# Altium schematic sheet RainbowClock.SchDoc
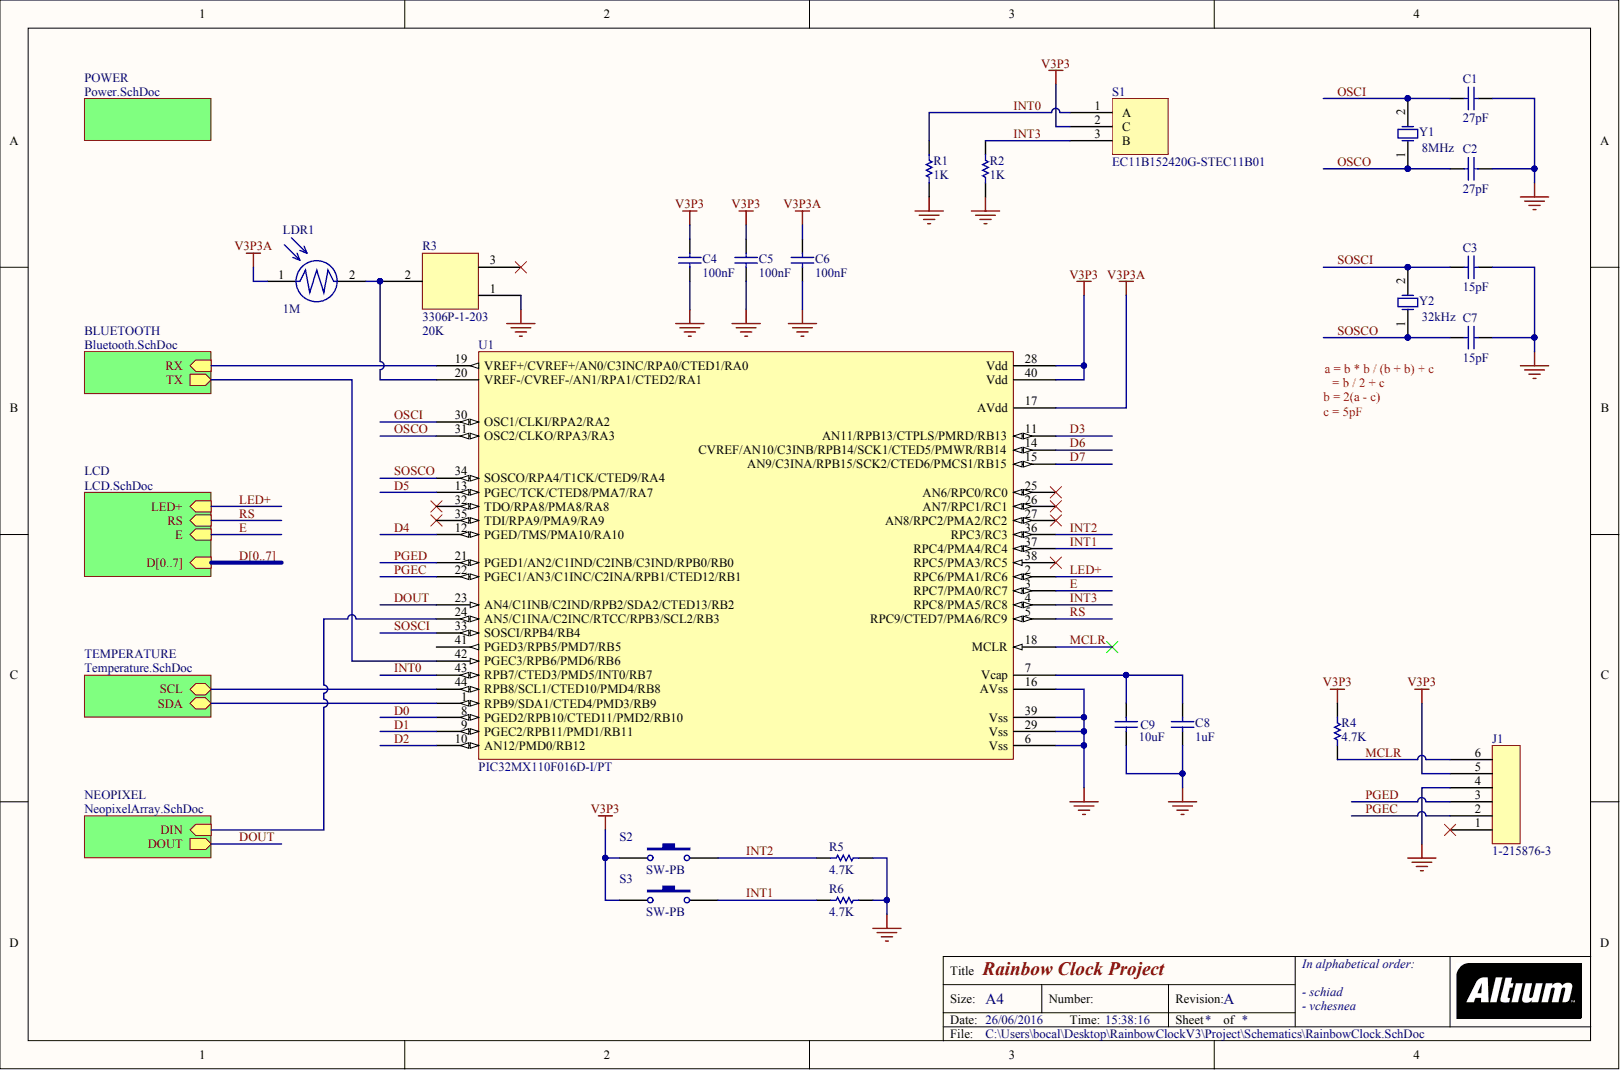
[diagram p0: RainbowClock.SchDoc]

## Parts
C1  27pF  [CAP0603]
C2  27pF  [CAP0603]
C3  15pF  [CAP0603]
C4  100nF  [CAP0603]
C5  100nF  [CAP0603]
C6  100nF  [CAP0603]
C7  15pF  [CAP0603]
C8  1uF  [CAP0603]
C9  10uF  [CAP0603]
J1  1-215876-3  [1-215876-3]
LDR1  LDR  value 1M  [VT935G]
R1  1K  [RES0603]
R2  1K  [RES0603]
R3  3306P-1-203  value 20K  [3306P-1-202]
R4  4.7K  [RES0603]
R5  4.7K  [RES0603]
R6  4.7K  [RES0603]
S1  EC11B152420G-STEC11B01  [EC11B152420G-STEC11B01]
S2  SW-PB  [1-1825027-4]
S3  SW-PB  [1-1825027-4]
U1  PIC32MX110F016D-I/PT  [PIC32MX110F016H-I/PT]  (value not drawn)
Y1  value 8MHz  [FOXSLF/080-20]  (hidden comment: 8MHz XTAL)
Y2  value 32kHz  [NCL38LF]  (hidden comment: 32kHz XTAL)

## Nets
GND: C1.2 C2.2 C3.2 C4.1 C5.1 C6.1 C7.2 C8.1 C9.1 J1.4 R1.2 R2.1 R3.1 R5.1 R6.1 U1.6(Vss) U1.16(AVss) U1.29(Vss) U1.39(Vss)
V3P3: C4.2 C5.2 J1.5 R4.2 S1.2 S2.1 S3.2 U1.28(Vdd) U1.40(Vdd)
V3P3A: C6.2 LDR1.1 U1.17(AVdd)
D0: U1.8(PGED2/RPB10/CTED11/PMD2/RB10)  [also labelled D]
D1: U1.9(PGEC2/RPB11/PMD1/RB11)  [also labelled D]
D2: U1.10(AN12/PMD0/RB12)  [also labelled D]
D3: U1.11(AN11/RPB13/CTPLS/PMRD/RB13)  [also labelled D]
D4: U1.12(PGED/TMS/PMA10/RA10)  [also labelled D]
D5: U1.13(PGEC/TCK/CTED8/PMA7/RA7)  [also labelled D]
D6: U1.14(CVREF/AN10/C3INB/RPB14/SCK1/CTED5/PMWR/RB14)  [also labelled D]
D7: U1.15(AN9/C3INA/RPB15/SCK2/CTED6/PMCS1/RB15)  [also labelled D]
DOUT: U1.23(AN4/C1INB/C2IND/RPB2/SDA2/CTED13/RB2)
E: U1.3(RPC7/PMA0/RC7)
INT0: R1.1 S1.1 U1.43(RPB7/CTED3/PMD5/INT0/RB7)
INT1: R6.2 S3.1 U1.37(RPC4/PMA4/RC4)
INT2: R5.2 S2.2 U1.36(RPC3/RC3)
INT3: R2.2 S1.3 U1.4(RPC8/PMA5/RC8)
LED+: U1.2(RPC6/PMA1/RC6)
MCLR: J1.6 R4.1 U1.18(MCLR)
OSCI: C1.1 U1.30(OSC1/CLKI/RPA2/RA2) Y1.2
OSCO: C2.1 U1.31(OSC2/CLKO/RPA3/RA3) Y1.1
PGEC: J1.2 U1.22(PGEC1/AN3/C1INC/C2INA/RPB1/CTED12/RB1)
PGED: J1.3 U1.21(PGED1/AN2/C1IND/C2INB/C3IND/RPB0/RB0)
RS: U1.5(RPC9/CTED7/PMA6/RC9)
SOSCI: C3.1 U1.33(SOSCI/RPB4/RB4) Y2.2
SOSCO: C7.1 U1.34(SOSCO/RPA4/T1CK/CTED9/RA4) Y2.1
NetC8_2: C8.2 C9.2 U1.7(Vcap)
NetJ1_1: J1.1
NetLDR1_2: LDR1.2 R3.2 U1.20(VREF-/CVREF-/AN1/RPA1/CTED2/RA1)
NetR3_3: R3.3
NetU1_1: U1.1(RPB9/SDA1/CTED4/PMD3/RB9)
NetU1_19: U1.19(VREF+/CVREF+/AN0/C3INC/RPA0/CTED1/RA0)
NetU1_24: U1.24(AN5/C1INA/C2INC/RTCC/RPB3/SCL2/RB3)
NetU1_25: U1.25(AN6/RPC0/RC0)
NetU1_26: U1.26(AN7/RPC1/RC1)
NetU1_27: U1.27(AN8/RPC2/PMA2/RC2)
NetU1_32: U1.32(TDO/RPA8/PMA8/RA8)
NetU1_35: U1.35(TDI/RPA9/PMA9/RA9)
NetU1_38: U1.38(RPC5/PMA3/RC5)
NetU1_41: U1.41(PGED3/RPB5/PMD7/RB5)
NetU1_42: U1.42(PGEC3/RPB6/PMD6/RB6)
NetU1_44: U1.44(RPB8/SCL1/CTED10/PMD4/RB8)

## Library data of the parts
C1-C9 = Cap: Capacitor; Component Kind: Standard; Library Name: Miscellaneous Devices.IntLib; Signal Integrity: Cap; Simulation: CAP
  C1 C2: Value: 27pF
  C3 C7: Value: 15pF
  C4-C6: Value: 100nF
  C8: Value: 1uF
  C9: Value: 10uF
J1 = 1-215876-3: Tyco 1-215876-3; Fabricant: TE Connectivity; Fournisseur: Farnell; RefFournisseur: 1773039; Component Kind: Standard; Library Name: Components.SchLib; RefFabricant: 1-215876-3
LDR1 = LDR: Photoresistor; Fabricant: Excelitas Tech; Fournisseur: Farnell; RefFournisseur: 1652638; Component Kind: Standard; Library Name: Components.SchLib; RefFabricant: VT935G
R1 R2 R4-R6 = Res1: Resistor; Component Kind: Standard; Library Name: Miscellaneous Devices.IntLib; Signal Integrity: Res; Simulation: RESISTOR
  R1 R2: Value: 1K
  R4-R6: Value: 4.7K
R3 = 3306P-1-202: Trimpot; Fabricant: Bourns; Fournisseur: Farnell; RefFournisseur: 108240; Component Kind: Standard; Library Name: Components.SchLib; RefFabricant: 3306P-1-203
S1 = EC11B152420G-STEC11B01: Rotary encoder; Fabricant: ALPS; Fournisseur: Farnell; RefFournisseur: 1191733; Component Kind: Standard; Library Name: Components.SchLib; RefFabricant: EC11B152420G-STEC11B01
S2 S3 = SW-PB: Switch; Fabricant: TE Connectivity; Fournisseur: Farnell; RefFournisseur: 2468742; Component Kind: Standard; Library Name: Miscellaneous Devices.IntLib; RefFabricant: 1-1825027-4
U1 = PIC32MX110F016D-I/PT: Microcontroller; Fabricant: Microchip; Fournisseur: Farnell; RefFournisseur: 2057068; Component Kind: Standard; Library Name: Components.SchLib; RefFabricant: PIC32MX110F016D-I/PT
Y1 = XTAL: Crystal Oscillator; Fabricant: Fox Electronics; Fournisseur: Farnell; RefFournisseur: 2057960; Component Kind: Standard; Library Name: Miscellaneous Devices.IntLib; RefFabricant: FOXSLF/080-20
Y2 = XTAL: Crystal Oscillator; Fabricant: Fox Electronics; Fournisseur: Farnell; RefFabricant: NC38LF-327; RefFournisseur: 2064058; Component Kind: Standard; Library Name: Miscellaneous Devices.IntLib; Simulation: XTAL
